# Altium schematic sheet USB-PHY.SchDoc(USB-PHY)
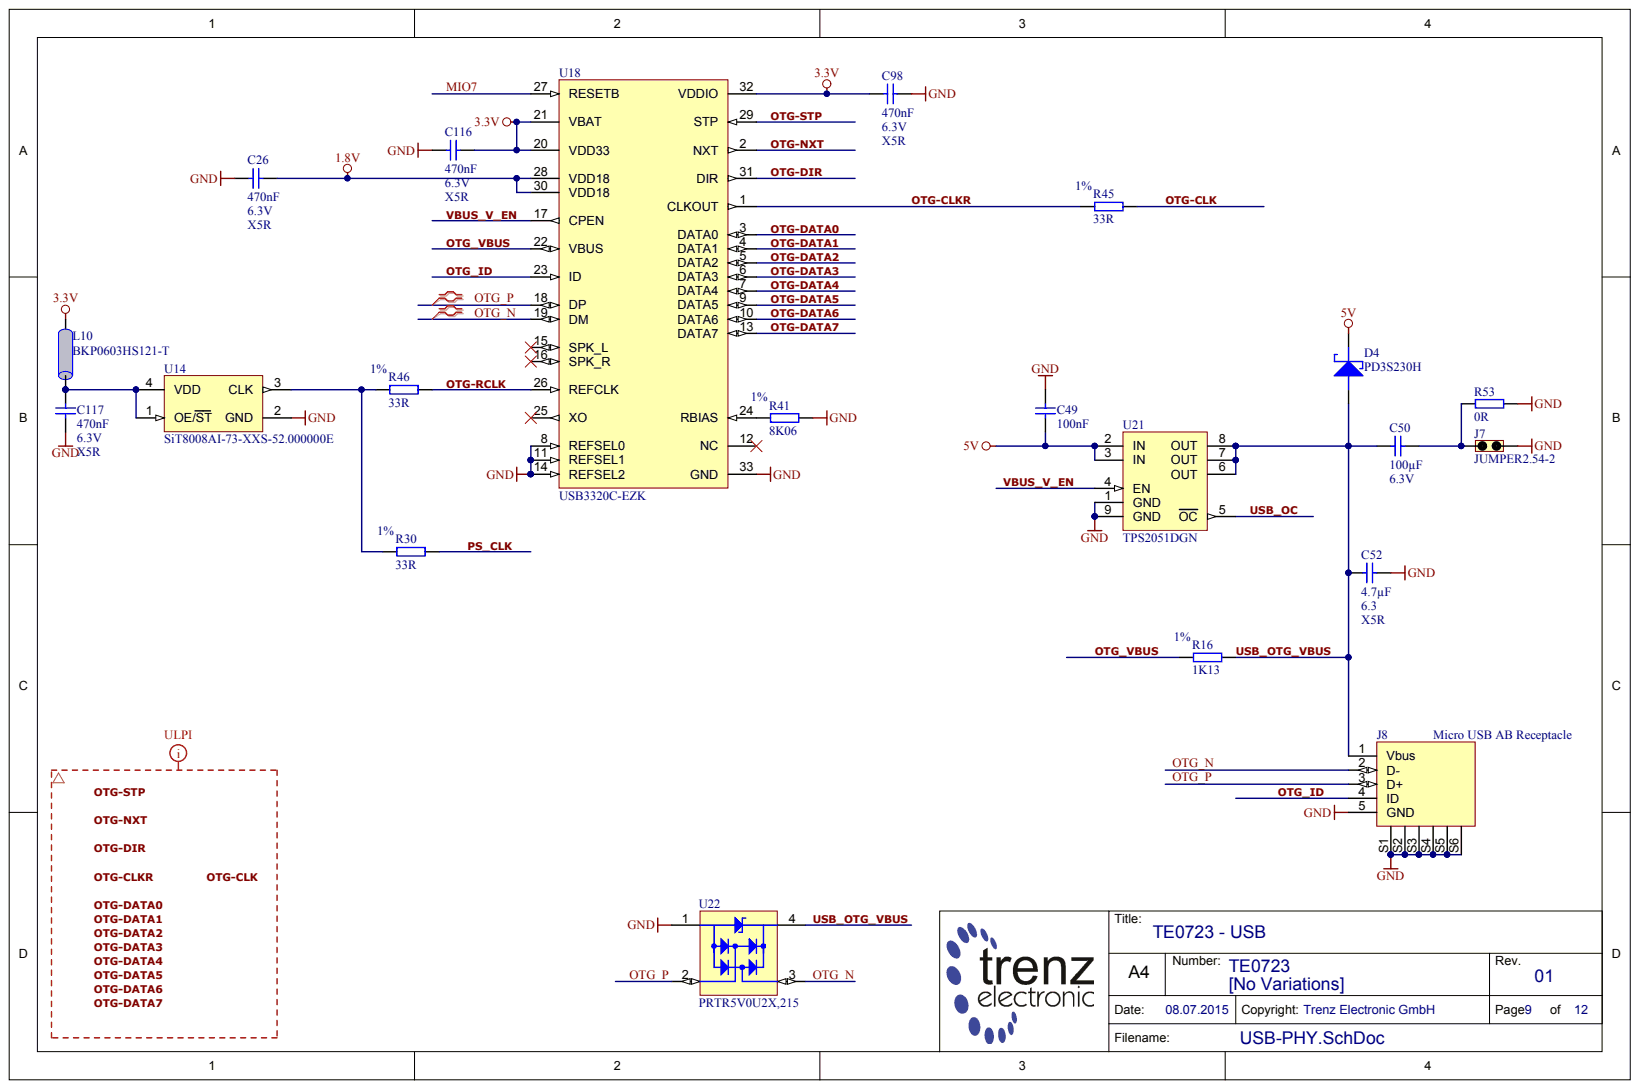
[diagram p0: USB-PHY.SchDoc]

## Parts
C26  470nF  Voltage: 6.3V  [CAPC0603X33N]
C49  100nF  [CAPC1005X55N]
C50  100µF  Voltage: 6.3V  [CAPC3216X180N]
C52  4.7µF  Voltage: 6.3  [CAPC1005X60N]
C98  470nF  Voltage: 6.3V  [CAPC0603X33N]
C116  470nF  Voltage: 6.3V  [CAPC0603X33N]
C117  470nF  Technology: X5R  Voltage: 6.3V  [CAPC0603X33N]
D4  PD3S230H  [PowerDI323]
J7  JUMPER2.54-2  [JUMPER2_54-2]
J8  Micro USB AB Receptacle  Case: Micro USB AB  [Micro USB ZX62-xx-5PA]
L10  BKP0603HS121-T  [INDC0603X33N]
R16  1K13  Tolerance: 1%  [RESC1005X40N]
R30  33R  Tolerance: 1%  [RESC0603X26N]
R41  8K06  Tolerance: 1%  [RESC1005X40N]
R45  33R  Tolerance: 1%  [RESC0603X26N]
R46  33R  Tolerance: 1%  [RESC0603X26N]
R53  0R  [RESC1005X40N]
U14  SiT8008AI-73-XXS-52.000000E  Database Table Name: CLK  [2016]
U18  USB3320C-EZK  [QFN50P500X500X100-33N-345]
U21  TPS2051DGN  [SOP65P488X107-9N-TI]
U22  PRTR5V0U2X,215  [SOT190P229X114-4N]

## Nets
1.8V: C26.1 U18.28(VDD18) U18.30(VDD18)
3.3V: C98.2 C116.1 L10.1 U18.20(VDD33) U18.21(VBAT) U18.32(VDDIO)
5V: C49.2 D4.1 U21.2(IN) U21.3(IN)
GND: C26.2 C49.1 C52.2 C98.1 C116.2 C117.2 J7.2 J8.5(GND) J8.S1 J8.S2 J8.S3 J8.S4 J8.S5 J8.S6 R41.2 R53.2 U14.2(GND) U18.8(REFSEL0) U18.11(REFSEL1) U18.14(REFSEL2) U18.33(GND) U21.1(GND) U21.9(GND) U22.1
MIO7: U18.27(RESETB)
OTG-CLK: R45.2
OTG-CLKR: R45.1 U18.1(CLKOUT)
OTG-DATA0: U18.3(DATA0)
OTG-DATA1: U18.4(DATA1)
OTG-DATA2: U18.5(DATA2)
OTG-DATA3: U18.6(DATA3)
OTG-DATA4: U18.7(DATA4)
OTG-DATA5: U18.9(DATA5)
OTG-DATA6: U18.10(DATA6)
OTG-DATA7: U18.13(DATA7)
OTG-DIR: U18.31(DIR)
OTG-NXT: U18.2(NXT)
OTG-RCLK: R46.2 U18.26(REFCLK)
OTG-STP: U18.29(STP)
OTG_ID: J8.4(ID) U18.23(ID)
OTG_N: J8.2(D-) U18.19(DM) U22.3
OTG_P: J8.3(D+) U18.18(DP) U22.2
OTG_VBUS: R16.1 U18.22(VBUS)
PS_CLK: R30.2
USB_OC: U21.5(OC)
USB_OTG_VBUS: C50.2 C52.1 D4.2 J8.1(Vbus) R16.2 U21.6(OUT) U21.7(OUT) U21.8(OUT) U22.4
VBUS_V_EN: U18.17(CPEN) U21.4(EN)
NetC50_1: C50.1 J7.1 R53.1
NetC117_1: C117.1 L10.2 U14.1(OE/ST) U14.4(VDD)
NetR30_1: R30.1 R46.1 U14.3(CLK)
NetR41_1: R41.1 U18.24(RBIAS)
N00436:

Not connected: U18 12(NC) 15(SPK_L) 16(SPK_R) 25(XO)

## Library data of the parts
C26 C98 C116 C117 = 24921: KON 470nF X5R 6.3V SMD 20%; Database Table Name: C_0603_0201; Mixed: SMT; Preferred: Yes; Value: 470n; AN: 24921; Case: 0603 (0201); Component Kind: Standard; Library Name: TE.DbLib; Tolerance: 20%
  C26 C98 C116: Technology: X5R
C49 = 26183: KON 100nF 50V SMD AEC-Q200; Database Table Name: A_C_1005_0402; Mixed: SMT; Tolerance: 10%; Value: 100n; AN: 26183; Case: 1005 (0402); Component Kind: Standard; Library Name: TE.DbLib; Technology: X7R; Voltage: 50V
C50 = 23116: KON 100µF 6,3V X5R SMD 20%; Database Table Name: C_3216_1206; Mixed: SMT; Preferred: Yes; Value: 100u; AN: 23116; Case: 3216 (1206); Component Kind: Standard; Library Name: TE.DbLib; Technology: X5R; Tolerance: 20%
C52 = 24719: KON 4.7µF 6.3V X5R SMD 20%; Database Table Name: C_1005_0402; Mixed: SMT; Preferred: Yes; Value: 4.7u; AN: 24719; Case: 1005 (0402); Component Kind: Standard; Library Name: TE.DbLib; Technology: X5R; Tolerance: 20%
D4 = 24682: Database Table Name: DIODE; Mixed: SMT; AN: 24682; Case: PowerDI323; Component Kind: Standard; Description: PD3S230H; Library Name: TE.DbLib; HelpURL: B:\AD\adlib\datasheet\PD3S230H
J7 = 22330: Stiftleiste gerade 1-reihig, 2pol.; Database Table Name: CON_HEADER; Mixed: THT; Trenz-Stock: Yes; AN: 22330; Component Kind: Standard; Library Name: TE.DbLib
J8 = 25126: Micro USB AB Buchse; Database Table Name: CON_IO; Mixed: SMT; Trenz-Stock: Yes; AN: 25126; Component Kind: Standard; Library Name: TE.DbLib; HelpURL: B:\AD\adlib\datasheet\USB\USB_2.0_Spec\Micro-USB_final
L10 = 25393: Ferritdurchführung SMD 0201; Database Table Name: L_0603_0201; Mixed: SMT; Preferred: Yes; Tolerance: 25%; AN: 25393; Case: 0603 (0201); Component Kind: Standard; Library Name: TE.DbLib; Trenz-Stock: Yes; HelpURL: B:\AD\adlib\datasheet\MULTILAYER CHIP BEAD INDUCTORS BK
R16 = 23160: WID SMD 1K13 1% 0,063W; Database Table Name: R_1005_0402; Mixed: SMT; Preferred: Yes; Value: 1.13K; AN: 23160; Case: 1005 (0402); Component Kind: Standard; Library Name: TE.DbLib
R30 R45 R46 = 25021: WID SMD 33R 1% 0,05W; Database Table Name: R_0603_0201; Mixed: SMT; Preferred: Yes; Value: 33; AN: 25021; Case: 0603 (0201); Component Kind: Standard; Library Name: TE.DbLib; HelpURL: B:\AD\adlib\datasheet\R0201
R41 = 25052: WID SMD 8K06 1% 0,063W; Database Table Name: R_1005_0402; Mixed: SMT; Preferred: Yes; Value: 8.06K; AN: 25052; Case: 1005 (0402); Component Kind: Standard; Library Name: TE.DbLib
R53 = 26222: WID SMD 0R 1% 0.063W AEC-Q200; Database Table Name: A_R_1005_0402; Mixed: SMT; Preferred: Yes; Value: 0; AN: 26222; Case: 1005 (0402); Component Kind: Standard; Library Name: TE.DbLib
U14 = 25009: SiT8008AI-73-XXS-52.000000E MEMS Oszillator 52MHz; Mixed: SMT; Preferred: Yes; Value: 52M; AN: 25009; Case: 2.0  x 1.6mm; Component Kind: Standard; Library Name: TE.DbLib; Trenz-Stock: Yes; HelpURL: B:\AD\adlib\datasheet\SiT8008
U18 = 24992: Database Table Name: IF; Mixed: SMT; Trenz-Stock: Yes; AN: 24992; Case: QFN32; Component Kind: Standard; Description: USB3320C-EZK; Library Name: TE.DbLib; HelpURL: B:\AD\adlib\datasheet\USB3320
U21 = 25167: TPS2051BDGN; Database Table Name: SWITCH; Mixed: SMT; Preferred: Yes; AN: 25167; Component Kind: Standard; Library Name: TE.DbLib; Trenz-Stock: Yes; HelpURL: B:\AD\adlib\datasheet\TPS2051BDGN
U22 = 24840: Database Table Name: DIODE; Mixed: SMT; Preferred: Yes; AN: 24840; Case: SOT143; Component Kind: Standard; Description: PRTR5V0U2X,215; Library Name: TE.DbLib; HelpURL: B:\AD\adlib\datasheet\CD143A-SR05
